# Altium schematic sheet Sheet5.SchDoc(Sheet5)
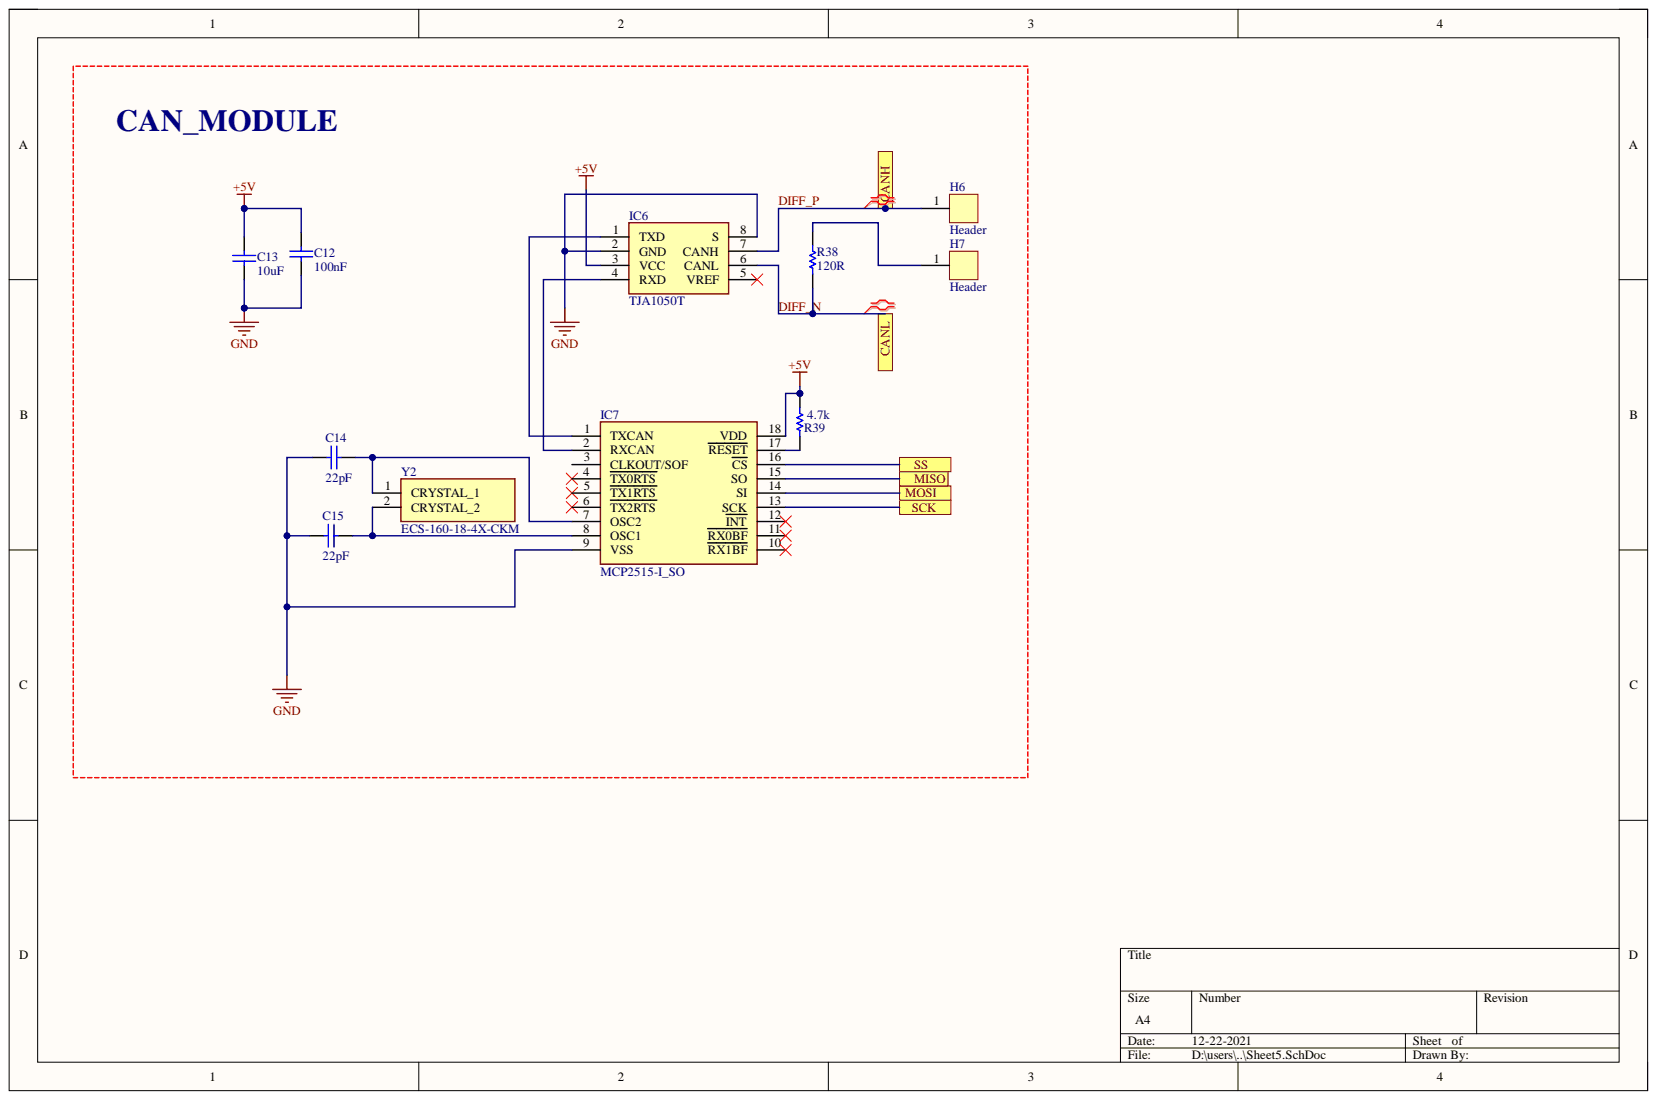
[diagram p0: Sheet5.SchDoc]

## Parts
C12  100nF  [KEMT-1206-2_N]
C13  10uF  [KEMT-1206-2_N]
C14  22pF  [KEMT-1206-2_N]
C15  22pF  [KEMT-1206-2_N]
H6  Header  [Header]
H7  Header  [Header]
IC6  TJA1050T  [SOIC127P600X175-8N]
IC7  MCP2515-I_SO  [SOIC127P1030X265-18N]
R38  120R  [R1206]
R39  4.7k  [R1206]
Y2  ECS-160-18-4X-CKM  [ECS160184XCKM]

## Ports
CANH, CANL, MISO, MOSI, SCK, SS

## Nets
+5V: C12.1 C13.1 IC6.3(VCC) IC7.18(VDD) R39.1
GND: C12.2 C13.2 C14.2 C15.2 IC6.2(GND) IC6.8(S) IC7.9(VSS)
DIFF_N: IC6.6(CANL) R38.1 ; port CANL
DIFF_P: H6.1 IC6.7(CANH) ; port CANH
MISO: IC7.15(SO) ; port MISO
MOSI: IC7.14(SI) ; port MOSI
SCK: IC7.13(SCK) ; port SCK
SS: IC7.16(CS) ; port SS
NetC14_1: C14.1 IC7.7(OSC2) Y2.1
NetC15_1: C15.1 IC7.8(OSC1) Y2.2
NetH7_1: H7.1 R38.2
NetIC6_1: IC6.1(TXD) IC7.1(TXCAN)
NetIC6_4: IC6.4(RXD) IC7.2(RXCAN)
NetIC7_17: IC7.17(RESET) R39.2

Not connected: IC6 5(VREF); IC7 3(CLKOUT/SOF) 4(TX0RTS) 5(TX1RTS) 6(TX2RTS) 10(RX1BF) 11(RX0BF) 12(INT)

## Library data of the parts
C12-C15 = CMP-1037-04202-2: CAP, Ceramic, 0.1 uF, +/- 10%, 50 V, -55 to 125 degC, 1206 (3216 Metric), RoHS, Tape and Reel; Manufacturer: Kemet; Capacitance: 0.1µF; Capacitor Case Style: 1206[3216Metric]; Dielectric Characteristic: X7R; Product Range: KEMET-CSeries; SVHC: ToBeAdvised; Voltage Rating: 50V; Capacitance Tolerance: ±10%; Component Kind: Standard; Datasheet URL: https://datasheet.ciiva.com/6125/1725438-6125494.pdf; Datasheet Version: Jul-13; Library Name: Schlib1.SchLib; Manufacturer URL: http://www.kemet.com/; Operating Temperature Max: 125degC; Operating Temperature Min: -55degC; Package Description: 2-Leads SMD, Body 3.2 x 1.6 mm; Package Reference: 1206-2; Packaging: Tape&Reel
IC6 = TJA1050T: Integrated Circuit; Manufacturer_Name: Nexperia; Component Kind: Standard; Datasheet Link: http://docs-emea.rs-online.com/webdocs/0425/0900766b80425301.pdf; Height: 1.75mm; Library Name: SamacSys.SchLib; Manufacturer_Part_Number: TJA1050T; Mouser Part Number: N/A; Mouser Price/Stock: https://www.mouser.com/Search/Refine.aspx?Keyword=N%2FA
IC7 = MCP2515-I_SO: Integrated Circuit; Manufacturer_Name: Microchip; Manufacturer_Part_Number: MCP2515-I/SO; Arrow Part Number: MCP2515-I/SO; Arrow Price/Stock: https://www.arrow.com/en/products/mcp2515-iso/microchip-technology?region=nac; Component Kind: Standard; Datasheet Link: http://ww1.microchip.com/downloads/en/DeviceDoc/21801G.pdf; Height: 2.65mm; Library Name: SamacSys.SchLib; Mouser Part Number: 579-MCP2515-I/SO; Mouser Price/Stock: https://www.mouser.co.uk/ProductDetail/Microchip-Technology/MCP2515-I-SO?qs=KwArPi4cUogGDbGnphOvtQ%3D%3D
R38 R39 = RES: Manufacturer: Vishay Dale; Part Number: CRCW120647K0FKEA; Component Kind: Standard; Library Name: SamacSys.SchLib
Y2 = ECS-160-18-4X-CKM: Crystal or Oscillator; Manufacturer_Name: ECS; Component Kind: Standard; Datasheet Link: https://www.mouser.be/datasheet/2/122/hc-49usx-791.pdf; Height: 3.5mm; Library Name: SamacSys.SchLib; Manufacturer_Part_Number: ECS-160-18-4X-CKM; Mouser Part Number: 520-ECS160-18-4X-CKM; Mouser Price/Stock: https://www.mouser.co.uk/ProductDetail/ECS/ECS-160-18-4X-CKM?qs=7MVldsJ5UawYqyimirccKw%3D%3D
H6 H7 = Header: Component Kind: Standard; Library Name: Schlib1.SchLib
